# Altium schematic sheet Power_Tree.SchDoc(Power_Tree)
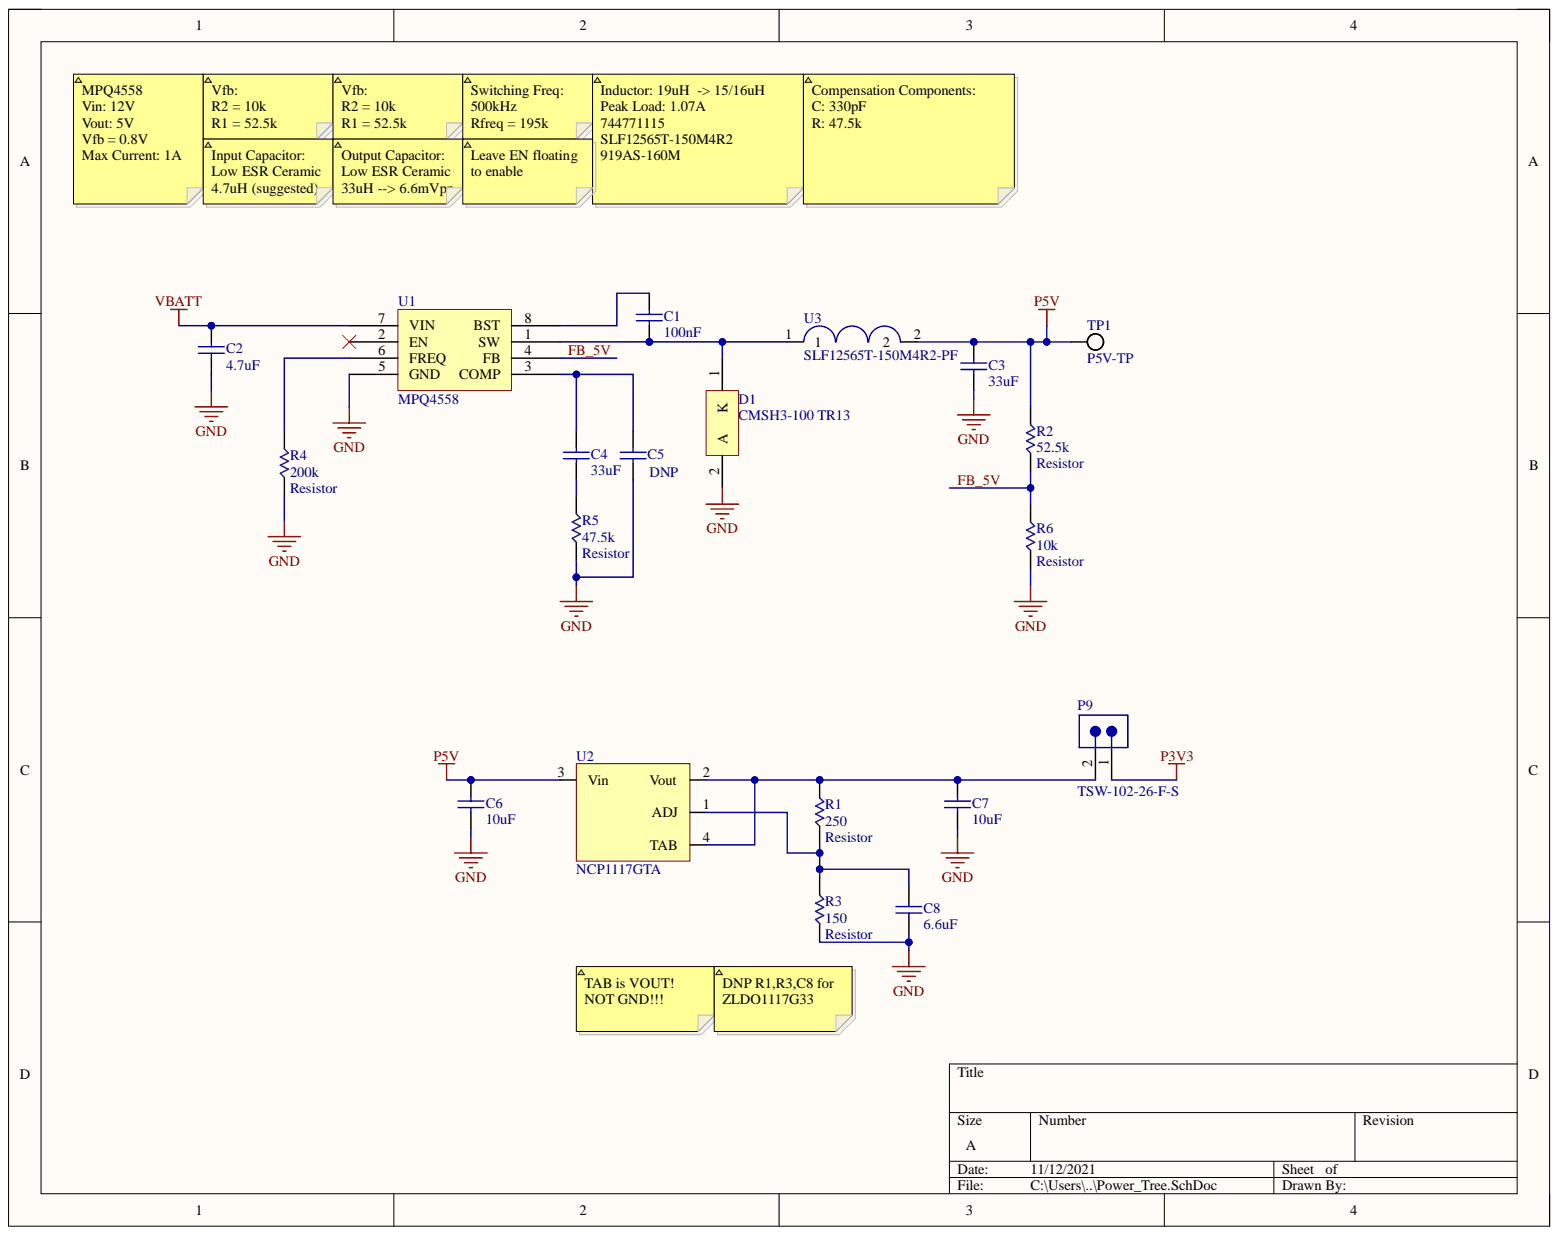
[diagram p0: Power_Tree.SchDoc]

## Parts
C1  value 100nF  [FOOTPRINT-1206-GEN]  (hidden comment: 100nF 1206)
C2  value 4.7uF  [FOOTPRINT-1206-GEN]  (hidden comment: CL31B475KBHVPNE)
C3  value 33uF  [FOOTPRINT-1206-GEN]
C4  value 33uF  [FOOTPRINT-1206-GEN]
C5  DNP  [FOOTPRINT-1206-GEN]
C6  value 10uF  [FOOTPRINT-1206-GEN]
C7  value 10uF  [FOOTPRINT-1206-GEN]
C8  value 6.6uF  [FOOTPRINT-1206-GEN]
D1  CMSH3-100 TR13  [ONSC-SMB-2-403A-03_V]
P9  TSW-102-26-F-S  [SMTC-TSW-102-26-XX-S]
R1  value 250  Type: Resistor  [FOOTPRINT-1206-GEN]  (hidden comment: Resistor 250 +/-1% 1206 1/4W)
R2  value 52.5k  Type: Resistor  [FOOTPRINT-1206-GEN]  (hidden comment: Resistor 52.5k +/-1% 1206 1/4W)
R3  value 150  Type: Resistor  [FOOTPRINT-1206-GEN]  (hidden comment: Resistor 150 +/-1% 1206 1/4W)
R4  value 200k  Type: Resistor  [FOOTPRINT-1206-GEN]  (hidden comment: Resistor 200k +/-1% 1206 1/4W)
R5  value 47.5k  Type: Resistor  [FOOTPRINT-1206-GEN]  (hidden comment: Resistor 47.5k +/-1% 1206 1/4W)
R6  value 10k  Type: Resistor  [FOOTPRINT-1206-GEN]  (hidden comment: Resistor 10k +/-1% 1206 1/4W)
TP1  P5V-TP  [FOOTPRINT-GENERIC-TP-VIA-1MM]  (no BOM)
U1  MPQ4558  [SOIC127P601X173-9N]
U2  NCP1117GTA  [SOT230P700X180-4N]
U3  SLF12565T-150M4R2-PF  [0.492" x 0.492"]

## Nets
GND: C2.1 C3.1 C5.1 C6.1 C7.1 C8.1 D1.2 R3.1 R4.1 R5.1 R6.1 U1.5(GND)
P3V3: P9.1
P5V: C3.2 C6.2 R2.2 TP1.1 U2.3(Vin) U3.2
VBATT: C2.2 U1.7(VIN)
FB_5V: R2.1 R6.2 U1.4(FB)
NetC1_1: C1.1 D1.1 U1.1(SW) U3.1
NetC1_2: C1.2 U1.8(BST)
NetC4_1: C4.1 R5.2
NetC4_2: C4.2 C5.2 U1.3(COMP)
NetC7_2: C7.2 P9.2 R1.2 U2.2(Vout) U2.4(TAB)
NetC8_2: C8.2 R1.1 R3.2 U2.1(ADJ)
NetR4_2: R4.2 U1.6(FREQ)

Not connected: U1 2(EN)

## Library data of the parts
C1 = CMP-001-00085-2: CAP CER 100nF 50V X7R 1206 ±10% 50V Ceramic Capacitor X7R 1206 (3216 Metric); Part Number: 1276-6781-1-ND; Component Kind: Standard; Design Item ID: Capacitor-Generic-1206; LatestRevisionNote: Re-released for DXP Platform.; PackageDescription: Radial Cap, Thru-Hole; 2 Leads; 0.3 in Pin Spacing; PackageReference: RAD-0.3; Published: 8-Jun-2000; Simulation: CAP; Source: Generic.SchLib; Type: Capacitor; Library Name: University of California Riverside - FSAE; Publisher: Altium Limited
C2 = CMP-001-00085-2: CAP CER 4.7UF 50V X7R 1206 ±10% 50V Ceramic Capacitor X7R 1206 (3216 Metric); Part Number: 1276-6781-1-ND; Component Kind: Standard; Design Item ID: Capacitor-Generic-1206; LatestRevisionNote: Re-released for DXP Platform.; PackageDescription: Radial Cap, Thru-Hole; 2 Leads; 0.3 in Pin Spacing; PackageReference: RAD-0.3; Published: 8-Jun-2000; Simulation: CAP; Source: Generic.SchLib; Type: Capacitor; Library Name: University of California Riverside - FSAE; Publisher: Altium Limited
C3 C4 = CMP-001-00085-2: CAP CER 33UF 50V X7R 1206 ±10% 50V Ceramic Capacitor X7R 1206 (3216 Metric); Part Number: 1276-6781-1-ND; Component Kind: Standard; Design Item ID: Capacitor-Generic-1206; LatestRevisionNote: Re-released for DXP Platform.; PackageDescription: Radial Cap, Thru-Hole; 2 Leads; 0.3 in Pin Spacing; PackageReference: RAD-0.3; Published: 8-Jun-2000; Simulation: CAP; Source: Generic.SchLib; Type: Capacitor; Library Name: University of California Riverside - FSAE; Publisher: Altium Limited
C5 = CMP-001-00085-2: 1206; Part Number: 1276-6781-1-ND; Type: Capacitor; Component Kind: Standard; Design Item ID: Capacitor-Generic-1206; LatestRevisionNote: Re-released for DXP Platform.; PackageDescription: Radial Cap, Thru-Hole; 2 Leads; 0.3 in Pin Spacing; PackageReference: RAD-0.3; Published: 8-Jun-2000; Simulation: CAP; Source: Generic.SchLib; Library Name: University of California Riverside - FSAE; Publisher: Altium Limited
C6 C7 = CMP-001-00085-2: CAP CER 10UF 50V X7R 1206 ±10% 50V Ceramic Capacitor X7R 1206 (3216 Metric); Part Number: 1276-6781-1-ND; Component Kind: Standard; Design Item ID: Capacitor-Generic-1206; LatestRevisionNote: Re-released for DXP Platform.; PackageDescription: Radial Cap, Thru-Hole; 2 Leads; 0.3 in Pin Spacing; PackageReference: RAD-0.3; Published: 8-Jun-2000; Simulation: CAP; Source: Generic.SchLib; Type: Capacitor; Library Name: University of California Riverside - FSAE; Publisher: Altium Limited
C8 = CMP-001-00085-2: CAP CER 6.6UF 50V X7R 1206 ±10% 50V Ceramic Capacitor X7R 1206 (3216 Metric); Part Number: 1276-6781-1-ND; Component Kind: Standard; Design Item ID: Capacitor-Generic-1206; LatestRevisionNote: Re-released for DXP Platform.; PackageDescription: Radial Cap, Thru-Hole; 2 Leads; 0.3 in Pin Spacing; PackageReference: RAD-0.3; Published: 8-Jun-2000; Simulation: CAP; Source: Generic.SchLib; Type: Capacitor; Library Name: University of California Riverside - FSAE; Publisher: Altium Limited
D1 = CMP-1055-00373-1: CMSH3-100 TR13 Schottky Power Rectifier, 2-Pin SMB, Pb-Free, Tape and Reel; Manufacturer: On Semiconductor; PartNumber: SS22T3G; Configuration: Single; IFSM Max (A): 75; Component Kind: Standard; ComponentLink1Description: Manufacturer URL; ComponentLink1URL: http://www.onsemi.com/; ComponentLink2Description: Datasheet; ComponentLink2URL: http://www.onsemi.cn/pub_link/Collateral/SS24-D.PDF; ComponentLink3Description: Package Specification; ComponentLink3URL: http://www.onsemi.com/pub_link/Collateral/403A-03.PDF; DatasheetVersion: Rev. 4; Library Name: Altium Content Vault; Mounting Technology: Surface Mount; PackageDescription: 2-Pin Small Molded Package, J-Bend Lead, Body 4.33 x 3.625 mm; PackageReference: SMB-2-403A-03; PackageVersion: Rev. J, 07/2012; Packing: Tape and Reel; RoHS: TRUE
P9 = CMP-002-00072-1: Headers & Wire Housings Classic PCB Header Strips, 0.100 pitch; Contact Post Length: 3.2mm; Gender: Male; Max Current Rating: 7.6A; Max Voltage Rating (AC): 550V; Number of Contacts: 2; Pitch: 2.54mm; Voltage Rating: 500V; Component Kind: Standard; Contact Gender: Male; Contact Material: Bronze; Contact Mating Length: 5.84mm; Contact Plating: Gold; Contact Type: -; Depth: 2.54mm; Flammability Rating: UL94 V-0; Housing Color: Black; Housing Material: Polyester; Length: 5.08mm; Max Operating Temperature: 125°C; Min Operating Temperature: -55°C; Number of Positions: 2; Number of Rows: 1; Orientation: Vertical; Package Quantity: 1; Packaging: Bulk; Radiation Hardening: No; REACH SVHC: No SVHC; RoHS Compliant: Yes; Series: TSW; Termination: Through Hole; Weight: 0.001257oz; Library Name: University of California Riverside - FSAE
R1 = CMP-009-00204-1: 250 ±1% 0.25W, 1/4W Chip Resistor 1206 (3216 Metric) Automotive AEC-Q200 Thick Film; Component Kind: Standard; Design Item ID: Resistor-Generic-1206-3216; Simulation: RESISTOR; Source: Generic.SchLib; Library Name: University of California Riverside - FSAE
R2 = CMP-009-00204-1: 52.5k ±1% 0.25W, 1/4W Chip Resistor 1206 (3216 Metric) Automotive AEC-Q200 Thick Film; Component Kind: Standard; Design Item ID: Resistor-Generic-1206-3216; Simulation: RESISTOR; Source: Generic.SchLib; Library Name: University of California Riverside - FSAE
R3 = CMP-009-00204-1: 150 ±1% 0.25W, 1/4W Chip Resistor 1206 (3216 Metric) Automotive AEC-Q200 Thick Film; Component Kind: Standard; Design Item ID: Resistor-Generic-1206-3216; Simulation: RESISTOR; Source: Generic.SchLib; Library Name: University of California Riverside - FSAE
R4 = CMP-009-00204-1: 200k ±1% 0.25W, 1/4W Chip Resistor 1206 (3216 Metric) Automotive AEC-Q200 Thick Film; Component Kind: Standard; Design Item ID: Resistor-Generic-1206-3216; Simulation: RESISTOR; Source: Generic.SchLib; Library Name: University of California Riverside - FSAE
R5 = CMP-009-00204-1: 47.5k ±1% 0.25W, 1/4W Chip Resistor 1206 (3216 Metric) Automotive AEC-Q200 Thick Film; Component Kind: Standard; Design Item ID: Resistor-Generic-1206-3216; Simulation: RESISTOR; Source: Generic.SchLib; Library Name: University of California Riverside - FSAE
R6 = CMP-009-00204-1: 10k ±1% 0.25W, 1/4W Chip Resistor 1206 (3216 Metric) Automotive AEC-Q200 Thick Film; Component Kind: Standard; Design Item ID: Resistor-Generic-1206-3216; Simulation: RESISTOR; Source: Generic.SchLib; Library Name: University of California Riverside - FSAE
TP1 = CMP-025-000000-1: Design Item ID: Misc-Generic-TP; Source: Generic.SchLib; Library Name: University of California Riverside - FSAE
U1 = CMP-047-000002-2: Component Kind: Standard; Design Item ID: MPQ4558; Source: Schlib1.SchLib; Library Name: University of California Riverside - FSAE
U2 = NCP1117GTA: Component Kind: Standard; Library Name: Schlib1.SchLib
U3 = SLF12565T-150M4R2-PF: Component Kind: Standard; Library Name: Schlib1.SchLib
